# Altium schematic sheet Schematic Prints("All Documents",Physical)
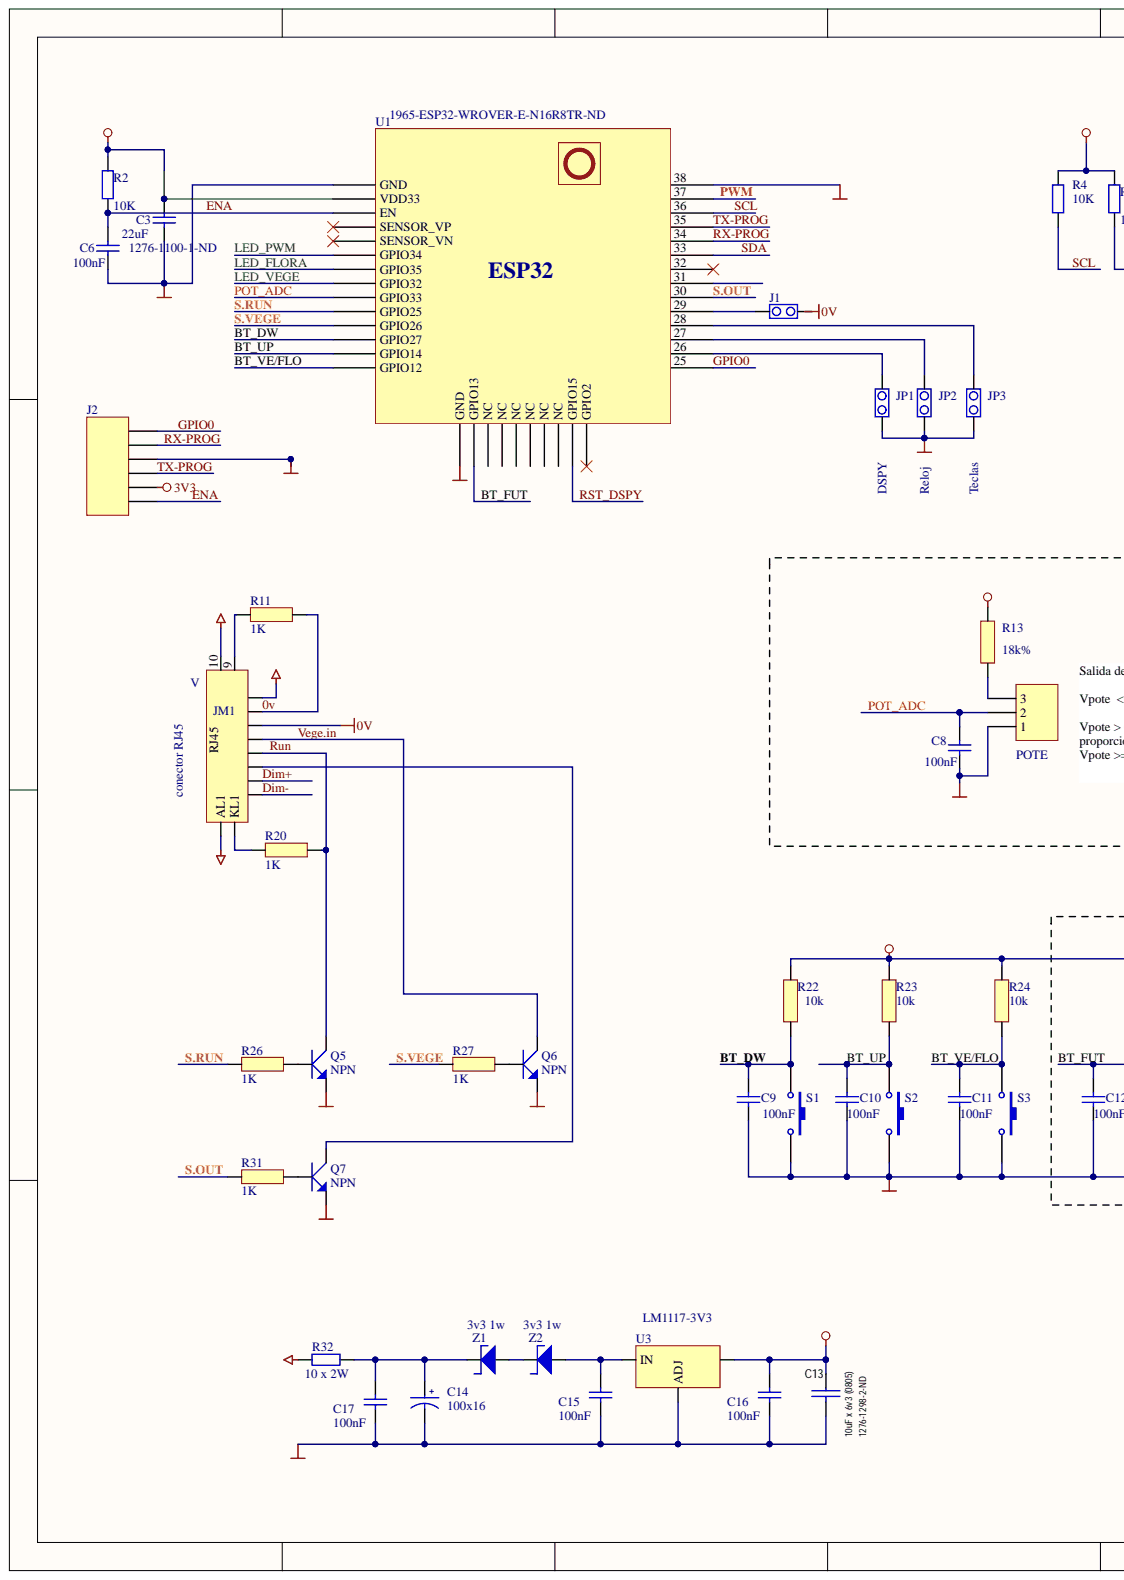
[diagram p0: Schematic Prints("All Documents",Physical), left]
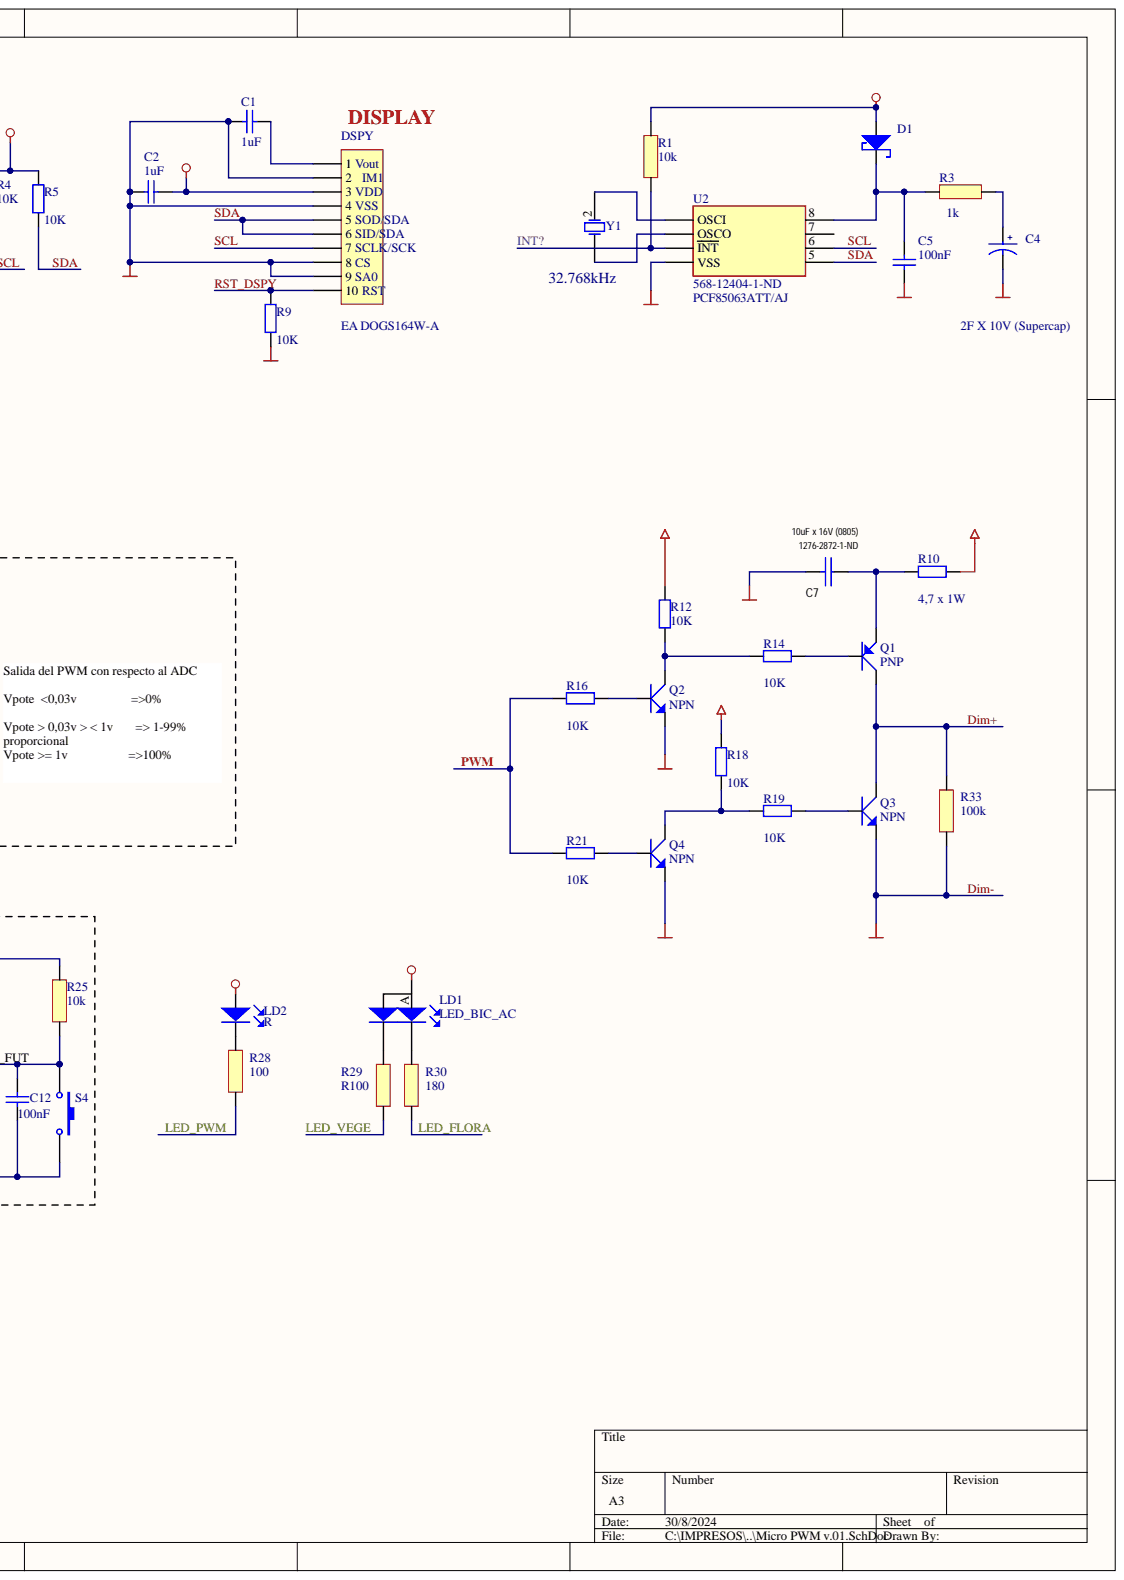
[diagram p1: Schematic Prints("All Documents",Physical), right]

## Parts
C1  value 1uF
C2  value 1uF
C3  1276-1100-1-ND  value 22uF
C4  2F X 10V (Supercap)
C5  value 100nF
C6  100nF
C7  10uF x 16V (0805)  Supplier Part Number 1: 1276-2872-1-ND  [0805]
C8  value 100nF
C9  value 100nF
C10  value 100nF
C11  value 100nF
C12  value 100nF
C13  10uF x 6v3 (0805)  Supplier Part Number 1: 1276-1298-2-ND  [0805]
C14  100x16
C15  value 100nF
C16  value 100nF
C17  value 100nF
D1  DIODE SCHOTTKY  (value not drawn)
DSPY  EA DOGS164W-A
J1  (no value)
J2  (no value)
JM1  conector RJ45
JP1  DSPY
JP2  Reloj
JP3  Teclas
LD1  LED_BIC_AC
LD2  R
POTE  (no value)
Q1  PNP
Q2  NPN
Q3  NPN
Q4  NPN
Q5  NPN
Q6  NPN
Q7  NPN
R1  10k
R2  value 10K
R3  1k
R4  value 10K
R5  value 10K
R9  value 10K
R10  value 4,7 x 1W
R11  1K
R12  value 10K
R13  18k%
R14  value 10K
R16  value 10K
R18  value 10K
R19  value 10K
R20  1K
R21  value 10K
R22  10k
R23  10k
R24  10k
R25  10k
R26  1K
R27  1K
R28  100
R29  R100
R30  180
R31  1K
R32  value 10 x 2W
R33  100k
S1  (no value)
S2  (no value)
S3  (no value)
S4  (no value)
U1  1965-ESP32-WROVER-E-N16R8TR-ND
U2  568-12404-1-ND  Manufacturer_Part_Number: PCF85063ATT/AJ
U3  LM1117-3V3  [LT117-3.3]
Y1  (no value)
Z1  3v3 1w
Z2  3v3 1w

## Nets
3V3: C2.1 C3.2 C13.1 C16.2 D1.A DSPY.3(3_VDD) J2.2 LD1.A LD2.A R1.1 R2.1 R4.1 R5.1 R9.2 R13.1 R22.1 R23.1 R24.1 R25.1 U1.2(VDD33) U3.2
12V: R10.2 R12.1 R18.2
+12: JM1.1 JM1.10 JM1.12(AL1) R32.1
0v: C1.2 C2.2 C3.1 C4.- C5.1 C6.1 C7.2 C8.1 C9.1 C10.1 C11.1 C12.1 C13.2 C14.- C15.1 C16.1 C17.1 DSPY.2 DSPY.4(4_VSS) DSPY.8(8_CS) DSPY.9(9_SA0) J2.4 JM1.2 JM1.3 JM1.8 JP1.2 JP2.2 JP3.2 POTE.1 Q2.E Q3.E Q4.E Q5.E Q6.E Q7.E R11.2 R33.2 S1.2 S2.2 S3.2 S4.2 U1.1(GND) U1.15(GND) U1.38 U2.4(VSS) U3.1
BT_DW: C9.2 R22.2 S1.1 U1.12(GPIO27)
BT_FUT: C12.2 R25.2 S4.1 U1.16(GPIO13)
BT_UP: C10.2 R23.2 S2.1 U1.13(GPIO14)
BT_VE/FLO: C11.2 R24.2 S3.1 U1.14(GPIO12)
Dim+: JM1.7 Q1.C Q3.C R33.1
ENA: C6.2 J2.1 R2.2 U1.3(EN)
GPIO0: J2.6 U1.25
INT?: R1.2 U2.3(INT)
LED_FLORA: R30.2 U1.7(GPIO35)
LED_PWM: R28.2 U1.6(GPIO34)
LED_VEGE: R29.2 U1.8(GPIO32)
POT_ADC: C8.2 POTE.2 U1.9(GPIO33)
PWM: R16.1 R21.1 U1.37
RST_DSPY: DSPY.10(10_RST) R9.1 U1.23(GPIO15)
Run: JM1.5 Q5.C R20.1
RX-PROG: J2.5 U1.34
S.OUT: R31.2 U1.30
S.RUN: R26.2 U1.10(GPIO25)
S.VEGE: R27.2 U1.11(GPIO26)
SCL: DSPY.7 R4.2 U1.36 U2.6
SDA: DSPY.5 DSPY.6 R5.2 U1.33 U2.5
TX-PROG: J2.3 U1.35
Vege.in: JM1.4 Q6.C
NetC1_1: C1.1 DSPY.1(1_Vout)
NetC4_+: C4.+ R3.1
NetC5_2: C5.2 D1.K R3.2 U2.8
NetC7_1: C7.1 Q1.E R10.1
NetC14_+: C14.+ C17.2 R32.2 Z1.K
NetC15_2: C15.2 U3.3 Z2.A
NetJ1_1: J1.1 U1.29
NetJ1_2: J1.2
NetJM1_6: JM1.6 Q7.C
NetJM1_9: JM1.9 R11.1
NetJM1_11: JM1.11(KL1) R20.2
NetJP1_1: JP1.1 U1.26
NetJP2_1: JP2.1 U1.27
NetJP3_1: JP3.1 U1.28
NetLD1_KR: LD1.KR R30.1
NetLD1_KV: LD1.KV R29.1
NetLD2_K: LD2.K R28.1
NetPOTE_3: POTE.3 R13.2
NetQ1_B: Q1.B R14.2
NetQ2_B: Q2.B R16.2
NetQ2_C: Q2.C R12.2 R14.1
NetQ3_B: Q3.B R19.2
NetQ4_B: Q4.B R21.2
NetQ4_C: Q4.C R18.1 R19.1
NetQ5_B: Q5.B R26.1
NetQ6_B: Q6.B R27.1
NetQ7_B: Q7.B R31.1
NetU1_4: U1.4(SENSOR_VP)
NetU1_5: U1.5(SENSOR_VN)
NetU1_17: U1.17(NC)
NetU1_18: U1.18(NC)
NetU1_19: U1.19(NC)
NetU1_20: U1.20(NC)
NetU1_21: U1.21(NC)
NetU1_22: U1.22(NC)
NetU1_24: U1.24(GPIO2)
NetU1_31: U1.31
NetU1_32: U1.32
NetU2_1: U2.1(OSCI) Y1.2
NetU2_2: U2.2(OSCO) Y1.1
NetU2_7: U2.7
NetZ1_A: Z1.A Z2.K

## Library data of the parts
C1-C3 C5 C6 C8-C12 C15-C17 = Cap: Library Name: Miscellaneous Devices.IntLib; Component Kind: Standard
  C1 C2 C5 C9-C12: Simulation: CAP; Signal Integrity: Cap; Published: 8-Jun-2000; PackageReference: RAD-0.3; PackageDescription: Radial Cap, Thru-Hole; 2 Leads; 0.3 in Pin Spacing; LatestRevisionNote: Re-released for DXP Platform.; LatestRevisionDate: 17-Jul-2002
  C3: Published: 8-Jun-2000; PackageReference: RAD-0.3; PackageDescription: Radial Cap, Thru-Hole; 2 Leads; 0.3 in Pin Spacing; LatestRevisionNote: Re-released for DXP Platform.; LatestRevisionDate: 17-Jul-2002
  C6: Value: 100nF
  C8 C15-C17: Simulation: CAP; Signal Integrity: Cap
  C1-C3 C5 C9-C12: Publisher: Altium Limited
C4 C14 = CAPACITOR POL: Text Field2: 30; Text Field1: 20; Component Kind: Standard; Library Name: Compo Genericos.SCHLIB
C7 C13 = CAPACITOR CERAMICO: Text Field2: 30; Text Field1: 20; Supplier 1: Digi-Key; Component Kind: Standard; Library Name: Compo Genericos.SCHLIB
DSPY = MHDR1X10: Library Name: Miscellaneous Connectors.IntLib; Component Kind: Standard
J1 JP1-JP3 = JUMPER2: Description: JUMPER2; Component Kind: Standard; Library Name: Varios.SCHLIB
J2 = Header 6: Header, 6-Pin; Library Name: Miscellaneous Connectors.IntLib; Component Kind: Standard
JM1 = RJ45: Description: RJ45; Component Kind: Standard; Library Name: Varios.SCHLIB
LD2 = LED: Text Field2: 20; Text Field1: 40; Component Kind: Standard; Library Name: Compo Genericos.SCHLIB
POTE = Header 3: Signal Integrity: Connector; Library Name: Miscellaneous Connectors.IntLib; LatestRevisionNote: Re-released for DXP Platform.; LatestRevisionDate: 17-Jul-2002; Component Kind: Standard; Publisher: Altium Limited
Q1 = PNP: Text Field2: 20; Text Field1: 20; Component Kind: Standard; Library Name: Compo Genericos.SCHLIB
Q2-Q7 = NPN: Text Field2: 20; Text Field1: 20; Component Kind: Standard; Library Name: Compo Genericos.SCHLIB
R1 R3 R11 R13 R20 R22-R31 R33 = R: Text Field2: 30; Text Field1: 20; Component Kind: Standard; Library Name: Compo Genericos.SCHLIB
R2 R4 R5 R9 R10 R12 R14 R16 R18 R19 R21 R32 = Res2: Published: 8-Jun-2000; PackageReference: AXIAL-0.4; PackageDescription: Axial Device, Thru-Hole; 2 Leads; 0.4 in Pin Spacing; Library Name: Miscellaneous Devices.IntLib; LatestRevisionNote: Re-released for DXP Platform.; LatestRevisionDate: 17-Jul-2002; Component Kind: Standard; Publisher: Altium Limited
  R4 R5 R9 R10 R12 R14 R16 R18 R19 R21 R32: Simulation: RESISTOR; Signal Integrity: Res
S1-S4 = SW-PB: Published: 24-Mar-1999; PackageReference: SPST-2; PackageDescription: Switch; 2 Leads; Library Name: Miscellaneous Devices.IntLib; LatestRevisionNote: Re-released for DXP Platform.; LatestRevisionDate: 17-Jul-2002; Component Kind: Standard; Publisher: Altium Limited
U1 = Component_1: Library Name: ESP32-WROOM-32UE.SchLib; Component Kind: Standard
U2 = PCF85063ATT_AJ: Component Kind: Standard
U3 = LM1117: LM1117 - Linear regulator; Library Name: Integrados.SCHLIB; Component Kind: Standard
Y1 = XTAL: Library Name: Miscellaneous Devices.IntLib; Component Kind: Standard
Z1 Z2 = DIODE ZENER: Text Field2: 20; Text Field1: 30; Component Kind: Standard; Library Name: Compo Genericos.SCHLIB
D1 = DIODE SCHOTTKY: Component Kind: Standard; Library Name: Compo Genericos.SCHLIB
LD1 = LED_BIC_AC: Component Kind: Standard; Library Name: Compo Genericos.SCHLIB
